# Altium schematic sheet PixelClockPanel.SchDoc
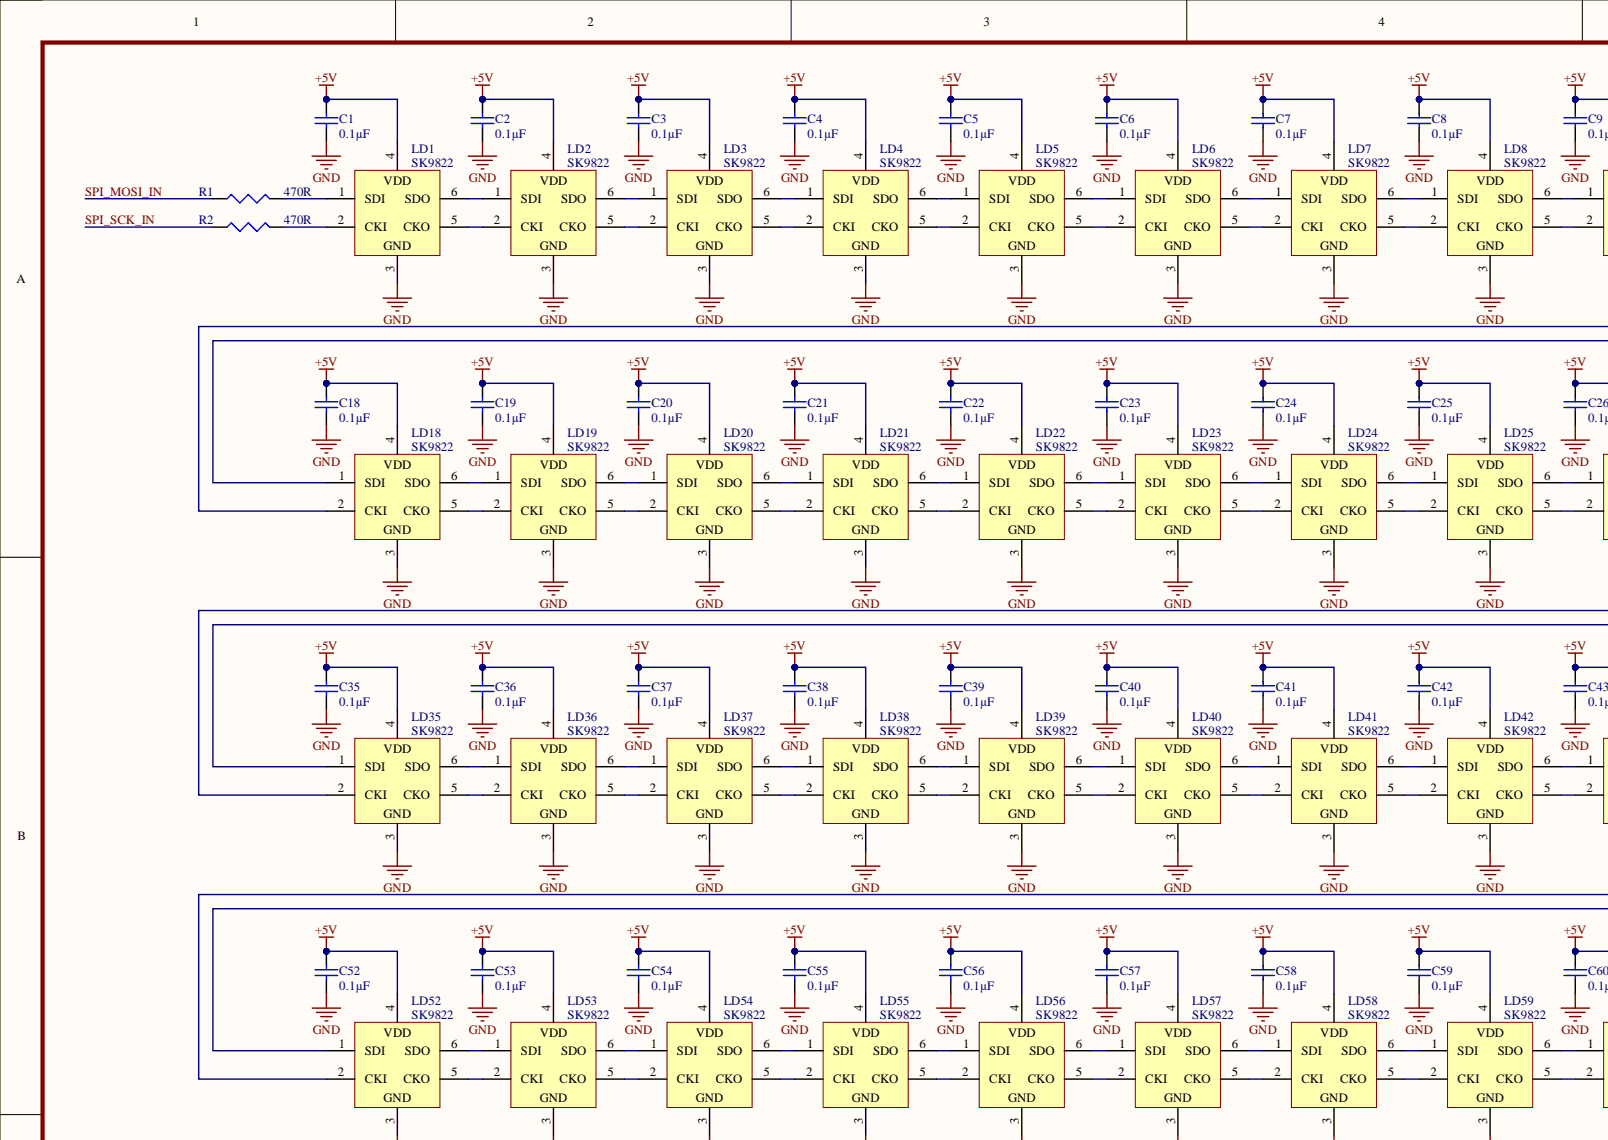
[diagram p0: PixelClockPanel.SchDoc, top left]
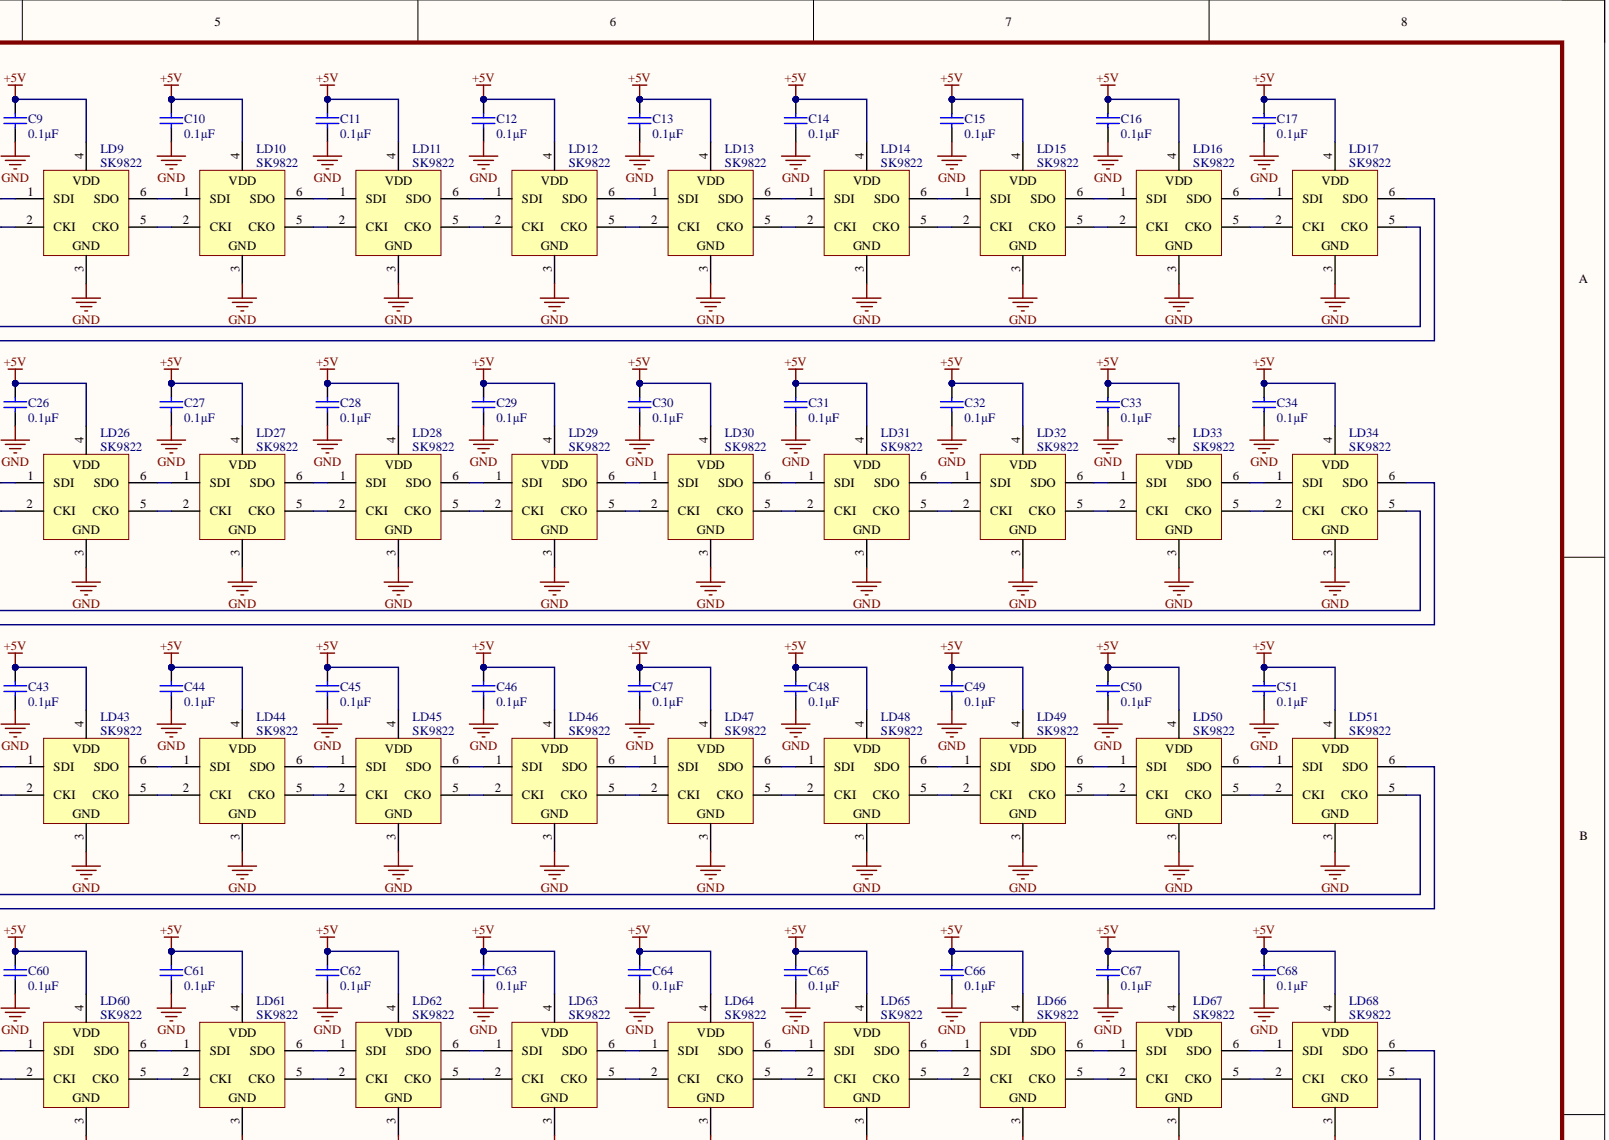
[diagram p1: PixelClockPanel.SchDoc, top right]
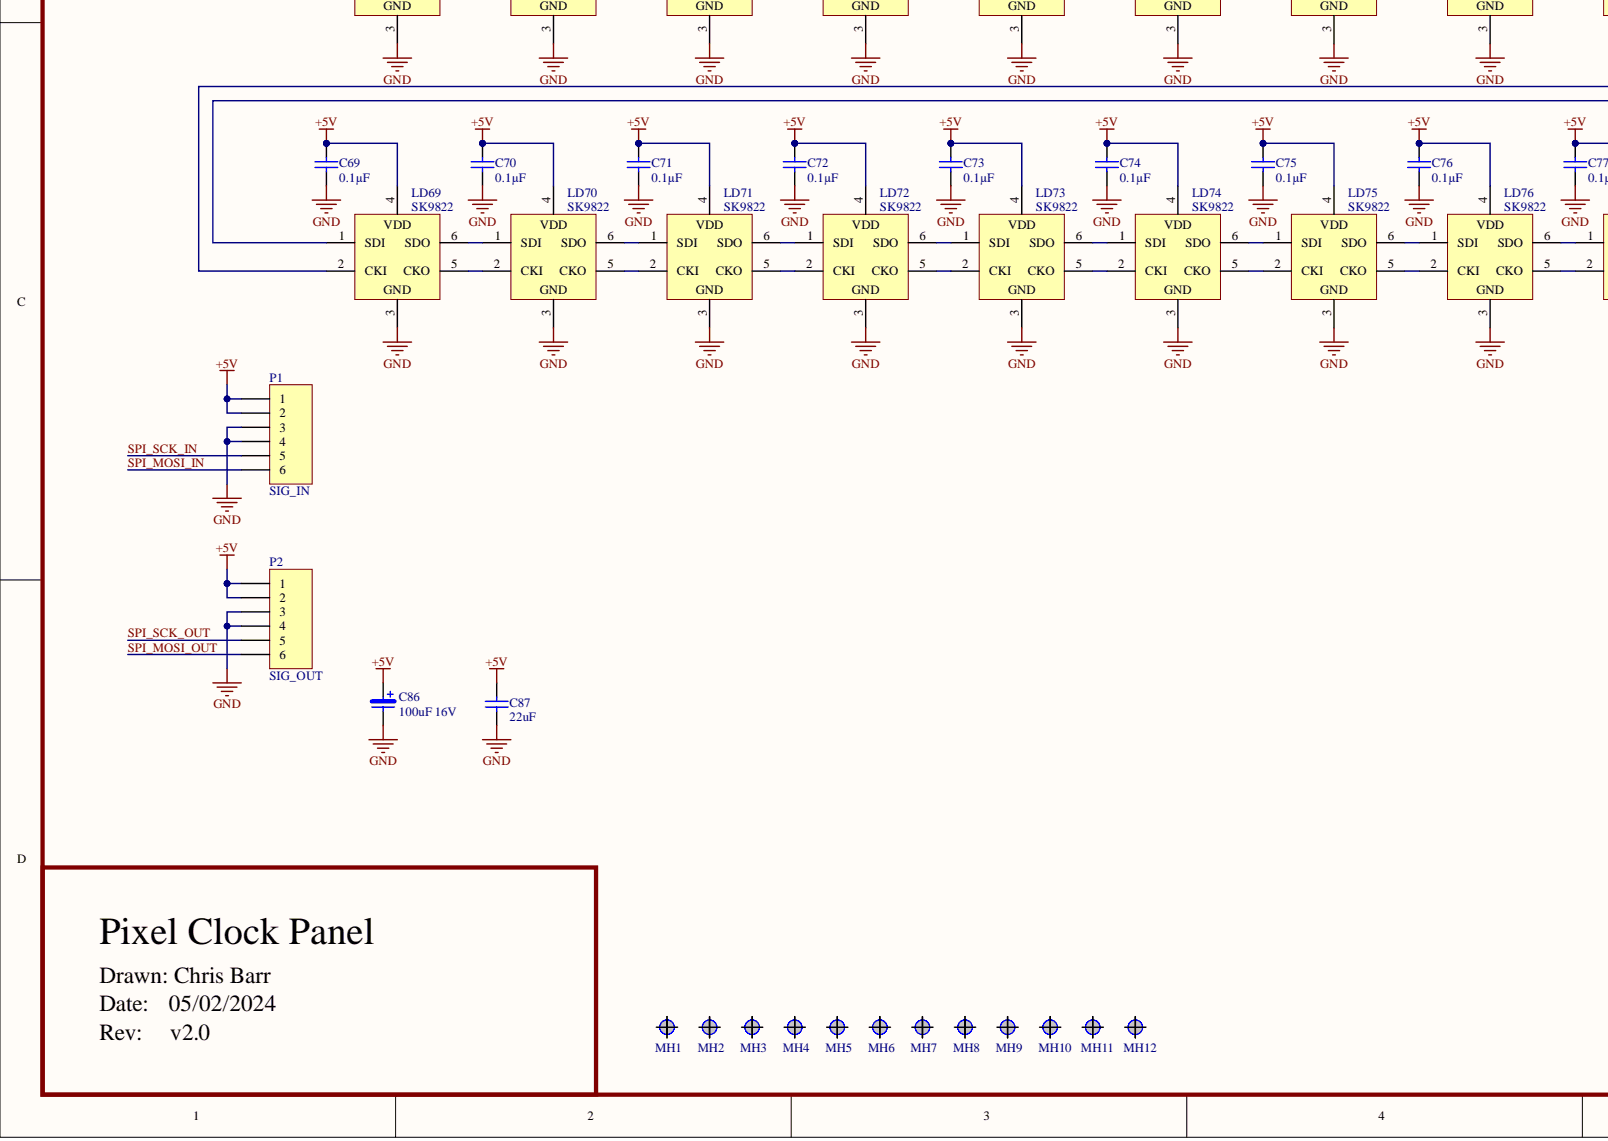
[diagram p2: PixelClockPanel.SchDoc, bottom left]
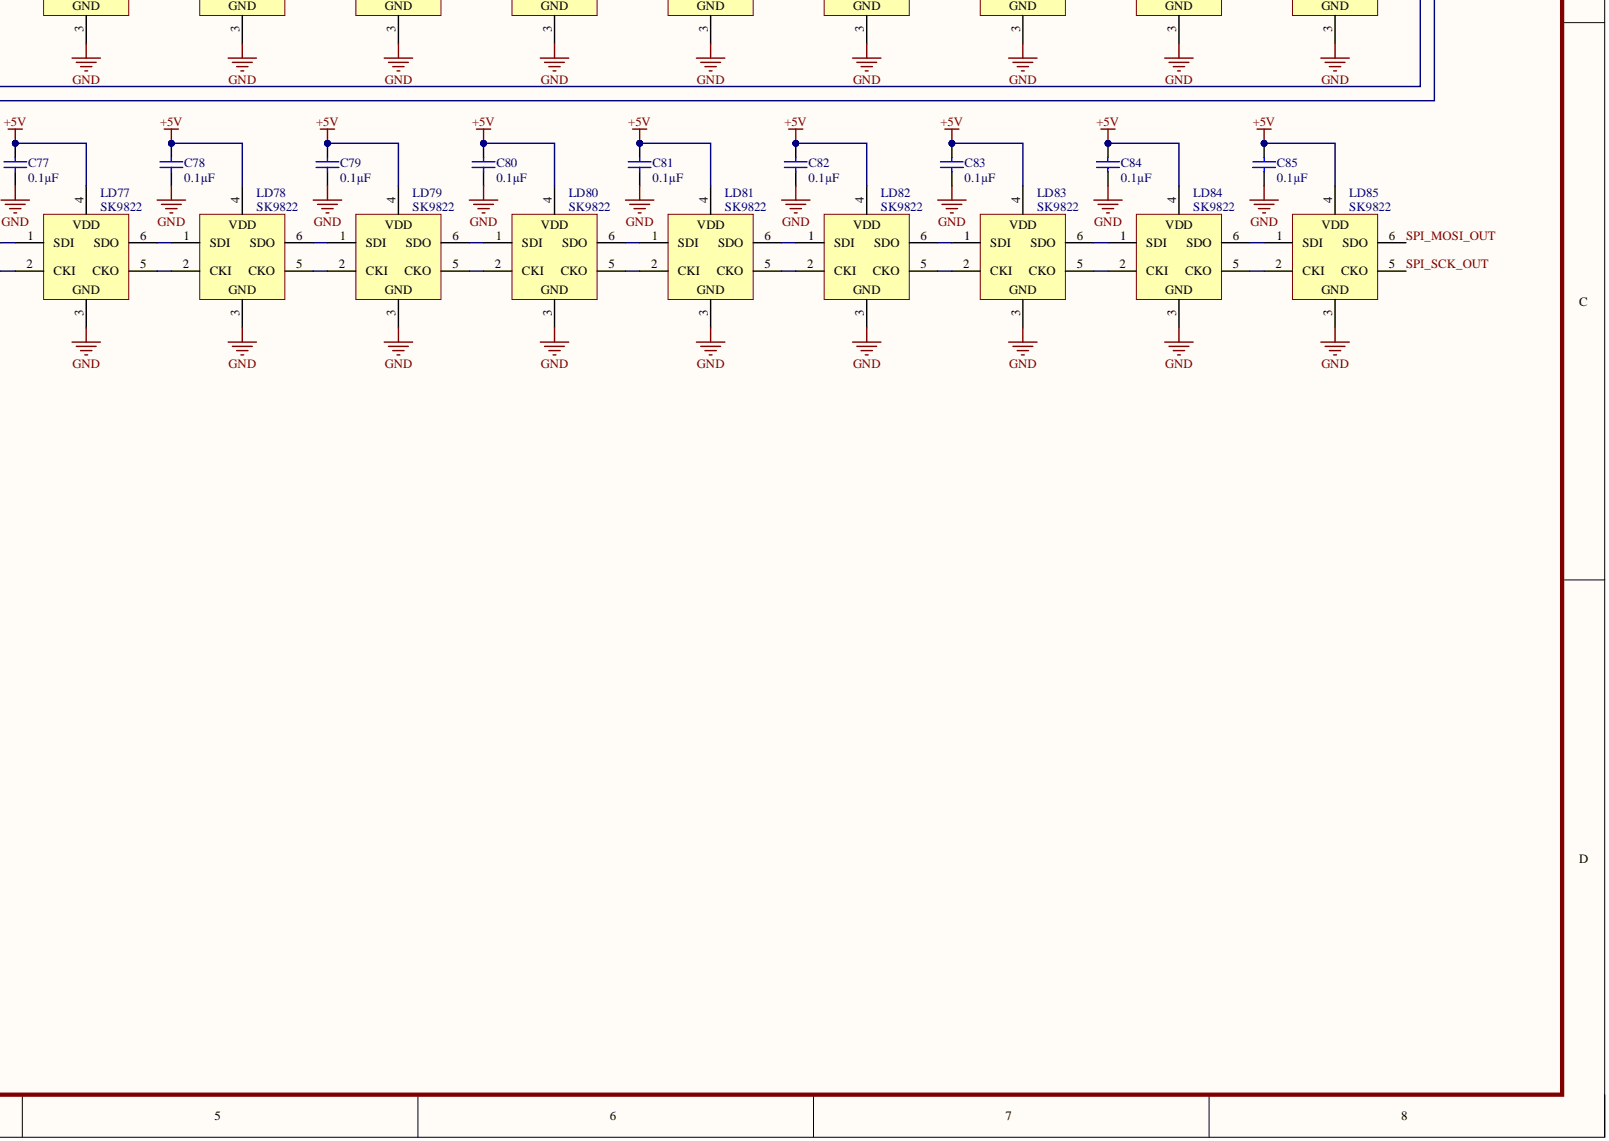
[diagram p3: PixelClockPanel.SchDoc, bottom right]

## Parts
C1  value 0.1µF  [CAPC1608X90N]  (hidden comment: Capacitor)
C2  value 0.1µF  [CAPC1608X90N]  (hidden comment: Capacitor)
C3  value 0.1µF  [CAPC1608X90N]  (hidden comment: Capacitor)
C4  value 0.1µF  [CAPC1608X90N]  (hidden comment: Capacitor)
C5  value 0.1µF  [CAPC1608X90N]  (hidden comment: Capacitor)
C6  value 0.1µF  [CAPC1608X90N]  (hidden comment: Capacitor)
C7  value 0.1µF  [CAPC1608X90N]  (hidden comment: Capacitor)
C8  value 0.1µF  [CAPC1608X90N]  (hidden comment: Capacitor)
C9  value 0.1µF  [CAPC1608X90N]  (hidden comment: Capacitor)
C10  value 0.1µF  [CAPC1608X90N]  (hidden comment: Capacitor)
C11  value 0.1µF  [CAPC1608X90N]  (hidden comment: Capacitor)
C12  value 0.1µF  [CAPC1608X90N]  (hidden comment: Capacitor)
C13  value 0.1µF  [CAPC1608X90N]  (hidden comment: Capacitor)
C14  value 0.1µF  [CAPC1608X90N]  (hidden comment: Capacitor)
C15  value 0.1µF  [CAPC1608X90N]  (hidden comment: Capacitor)
C16  value 0.1µF  [CAPC1608X90N]  (hidden comment: Capacitor)
C17  value 0.1µF  [CAPC1608X90N]  (hidden comment: Capacitor)
C18  value 0.1µF  [CAPC1608X90N]  (hidden comment: Capacitor)
C19  value 0.1µF  [CAPC1608X90N]  (hidden comment: Capacitor)
C20  value 0.1µF  [CAPC1608X90N]  (hidden comment: Capacitor)
C21  value 0.1µF  [CAPC1608X90N]  (hidden comment: Capacitor)
C22  value 0.1µF  [CAPC1608X90N]  (hidden comment: Capacitor)
C23  value 0.1µF  [CAPC1608X90N]  (hidden comment: Capacitor)
C24  value 0.1µF  [CAPC1608X90N]  (hidden comment: Capacitor)
C25  value 0.1µF  [CAPC1608X90N]  (hidden comment: Capacitor)
C26  value 0.1µF  [CAPC1608X90N]  (hidden comment: Capacitor)
C27  value 0.1µF  [CAPC1608X90N]  (hidden comment: Capacitor)
C28  value 0.1µF  [CAPC1608X90N]  (hidden comment: Capacitor)
C29  value 0.1µF  [CAPC1608X90N]  (hidden comment: Capacitor)
C30  value 0.1µF  [CAPC1608X90N]  (hidden comment: Capacitor)
C31  value 0.1µF  [CAPC1608X90N]  (hidden comment: Capacitor)
C32  value 0.1µF  [CAPC1608X90N]  (hidden comment: Capacitor)
C33  value 0.1µF  [CAPC1608X90N]  (hidden comment: Capacitor)
C34  value 0.1µF  [CAPC1608X90N]  (hidden comment: Capacitor)
C35  value 0.1µF  [CAPC1608X90N]  (hidden comment: Capacitor)
C36  value 0.1µF  [CAPC1608X90N]  (hidden comment: Capacitor)
C37  value 0.1µF  [CAPC1608X90N]  (hidden comment: Capacitor)
C38  value 0.1µF  [CAPC1608X90N]  (hidden comment: Capacitor)
C39  value 0.1µF  [CAPC1608X90N]  (hidden comment: Capacitor)
C40  value 0.1µF  [CAPC1608X90N]  (hidden comment: Capacitor)
C41  value 0.1µF  [CAPC1608X90N]  (hidden comment: Capacitor)
C42  value 0.1µF  [CAPC1608X90N]  (hidden comment: Capacitor)
C43  value 0.1µF  [CAPC1608X90N]  (hidden comment: Capacitor)
C44  value 0.1µF  [CAPC1608X90N]  (hidden comment: Capacitor)
C45  value 0.1µF  [CAPC1608X90N]  (hidden comment: Capacitor)
C46  value 0.1µF  [CAPC1608X90N]  (hidden comment: Capacitor)
C47  value 0.1µF  [CAPC1608X90N]  (hidden comment: Capacitor)
C48  value 0.1µF  [CAPC1608X90N]  (hidden comment: Capacitor)
C49  value 0.1µF  [CAPC1608X90N]  (hidden comment: Capacitor)
C50  value 0.1µF  [CAPC1608X90N]  (hidden comment: Capacitor)
C51  value 0.1µF  [CAPC1608X90N]  (hidden comment: Capacitor)
C52  value 0.1µF  [CAPC1608X90N]  (hidden comment: Capacitor)
C53  value 0.1µF  [CAPC1608X90N]  (hidden comment: Capacitor)
C54  value 0.1µF  [CAPC1608X90N]  (hidden comment: Capacitor)
C55  value 0.1µF  [CAPC1608X90N]  (hidden comment: Capacitor)
C56  value 0.1µF  [CAPC1608X90N]  (hidden comment: Capacitor)
C57  value 0.1µF  [CAPC1608X90N]  (hidden comment: Capacitor)
C58  value 0.1µF  [CAPC1608X90N]  (hidden comment: Capacitor)
C59  value 0.1µF  [CAPC1608X90N]  (hidden comment: Capacitor)
C60  value 0.1µF  [CAPC1608X90N]  (hidden comment: Capacitor)
C61  value 0.1µF  [CAPC1608X90N]  (hidden comment: Capacitor)
C62  value 0.1µF  [CAPC1608X90N]  (hidden comment: Capacitor)
C63  value 0.1µF  [CAPC1608X90N]  (hidden comment: Capacitor)
C64  value 0.1µF  [CAPC1608X90N]  (hidden comment: Capacitor)
C65  value 0.1µF  [CAPC1608X90N]  (hidden comment: Capacitor)
C66  value 0.1µF  [CAPC1608X90N]  (hidden comment: Capacitor)
C67  value 0.1µF  [CAPC1608X90N]  (hidden comment: Capacitor)
C68  value 0.1µF  [CAPC1608X90N]  (hidden comment: Capacitor)
C69  value 0.1µF  [CAPC1608X90N]  (hidden comment: Capacitor)
C70  value 0.1µF  [CAPC1608X90N]  (hidden comment: Capacitor)
C71  value 0.1µF  [CAPC1608X90N]  (hidden comment: Capacitor)
C72  value 0.1µF  [CAPC1608X90N]  (hidden comment: Capacitor)
C73  value 0.1µF  [CAPC1608X90N]  (hidden comment: Capacitor)
C74  value 0.1µF  [CAPC1608X90N]  (hidden comment: Capacitor)
C75  value 0.1µF  [CAPC1608X90N]  (hidden comment: Capacitor)
C76  value 0.1µF  [CAPC1608X90N]  (hidden comment: Capacitor)
C77  value 0.1µF  [CAPC1608X90N]  (hidden comment: Capacitor)
C78  value 0.1µF  [CAPC1608X90N]  (hidden comment: Capacitor)
C79  value 0.1µF  [CAPC1608X90N]  (hidden comment: Capacitor)
C80  value 0.1µF  [CAPC1608X90N]  (hidden comment: Capacitor)
C81  value 0.1µF  [CAPC1608X90N]  (hidden comment: Capacitor)
C82  value 0.1µF  [CAPC1608X90N]  (hidden comment: Capacitor)
C83  value 0.1µF  [CAPC1608X90N]  (hidden comment: Capacitor)
C84  value 0.1µF  [CAPC1608X90N]  (hidden comment: Capacitor)
C85  value 0.1µF  [CAPC1608X90N]  (hidden comment: Capacitor)
C86  value 100uF 16V  [CAP EL PANASONIC]  (hidden comment: CapE-6.3-100uF-16V)  (no BOM)
C87  value 22uF  [CAP 3225-1210]  (hidden comment: CapC-1210-22uF-16V)  (no BOM)
LD1  SK9822  [LED SK9822 APA102]
LD2  SK9822  [LED SK9822 APA102]
LD3  SK9822  [LED SK9822 APA102]
LD4  SK9822  [LED SK9822 APA102]
LD5  SK9822  [LED SK9822 APA102]
LD6  SK9822  [LED SK9822 APA102]
LD7  SK9822  [LED SK9822 APA102]
LD8  SK9822  [LED SK9822 APA102]
LD9  SK9822  [LED SK9822 APA102]
LD10  SK9822  [LED SK9822 APA102]
LD11  SK9822  [LED SK9822 APA102]
LD12  SK9822  [LED SK9822 APA102]
LD13  SK9822  [LED SK9822 APA102]
LD14  SK9822  [LED SK9822 APA102]
LD15  SK9822  [LED SK9822 APA102]
LD16  SK9822  [LED SK9822 APA102]
LD17  SK9822  [LED SK9822 APA102]
LD18  SK9822  [LED SK9822 APA102]
LD19  SK9822  [LED SK9822 APA102]
LD20  SK9822  [LED SK9822 APA102]
LD21  SK9822  [LED SK9822 APA102]
LD22  SK9822  [LED SK9822 APA102]
LD23  SK9822  [LED SK9822 APA102]
LD24  SK9822  [LED SK9822 APA102]
LD25  SK9822  [LED SK9822 APA102]
LD26  SK9822  [LED SK9822 APA102]
LD27  SK9822  [LED SK9822 APA102]
LD28  SK9822  [LED SK9822 APA102]
LD29  SK9822  [LED SK9822 APA102]
LD30  SK9822  [LED SK9822 APA102]
LD31  SK9822  [LED SK9822 APA102]
LD32  SK9822  [LED SK9822 APA102]
LD33  SK9822  [LED SK9822 APA102]
LD34  SK9822  [LED SK9822 APA102]
LD35  SK9822  [LED SK9822 APA102]
LD36  SK9822  [LED SK9822 APA102]
LD37  SK9822  [LED SK9822 APA102]
LD38  SK9822  [LED SK9822 APA102]
LD39  SK9822  [LED SK9822 APA102]
LD40  SK9822  [LED SK9822 APA102]
LD41  SK9822  [LED SK9822 APA102]
LD42  SK9822  [LED SK9822 APA102]
LD43  SK9822  [LED SK9822 APA102]
LD44  SK9822  [LED SK9822 APA102]
LD45  SK9822  [LED SK9822 APA102]
LD46  SK9822  [LED SK9822 APA102]
LD47  SK9822  [LED SK9822 APA102]
LD48  SK9822  [LED SK9822 APA102]
LD49  SK9822  [LED SK9822 APA102]
LD50  SK9822  [LED SK9822 APA102]
LD51  SK9822  [LED SK9822 APA102]
LD52  SK9822  [LED SK9822 APA102]
LD53  SK9822  [LED SK9822 APA102]
LD54  SK9822  [LED SK9822 APA102]
LD55  SK9822  [LED SK9822 APA102]
LD56  SK9822  [LED SK9822 APA102]
LD57  SK9822  [LED SK9822 APA102]
LD58  SK9822  [LED SK9822 APA102]
LD59  SK9822  [LED SK9822 APA102]
LD60  SK9822  [LED SK9822 APA102]
LD61  SK9822  [LED SK9822 APA102]
LD62  SK9822  [LED SK9822 APA102]
LD63  SK9822  [LED SK9822 APA102]
LD64  SK9822  [LED SK9822 APA102]
LD65  SK9822  [LED SK9822 APA102]
LD66  SK9822  [LED SK9822 APA102]
LD67  SK9822  [LED SK9822 APA102]
LD68  SK9822  [LED SK9822 APA102]
LD69  SK9822  [LED SK9822 APA102]
LD70  SK9822  [LED SK9822 APA102]
LD71  SK9822  [LED SK9822 APA102]
LD72  SK9822  [LED SK9822 APA102]
LD73  SK9822  [LED SK9822 APA102]
LD74  SK9822  [LED SK9822 APA102]
LD75  SK9822  [LED SK9822 APA102]
LD76  SK9822  [LED SK9822 APA102]
LD77  SK9822  [LED SK9822 APA102]
LD78  SK9822  [LED SK9822 APA102]
LD79  SK9822  [LED SK9822 APA102]
LD80  SK9822  [LED SK9822 APA102]
LD81  SK9822  [LED SK9822 APA102]
LD82  SK9822  [LED SK9822 APA102]
LD83  SK9822  [LED SK9822 APA102]
LD84  SK9822  [LED SK9822 APA102]
LD85  SK9822  [LED SK9822 APA102]
MH1  Screw Hole  [M3-Hole-2]  (value not drawn)  (no BOM)
MH2  Screw Hole  [M3-Hole-2]  (value not drawn)  (no BOM)
MH3  Screw Hole  [M3-Hole-2]  (value not drawn)  (no BOM)
MH4  Screw Hole  [M3-Hole-2]  (value not drawn)  (no BOM)
MH5  Screw Hole  [M3-Hole-2]  (value not drawn)  (no BOM)
MH6  Screw Hole  [M3-Hole-2]  (value not drawn)  (no BOM)
MH7  Screw Hole  [M3-Hole-2]  (value not drawn)  (no BOM)
MH8  Screw Hole  [M3-Hole-2]  (value not drawn)  (no BOM)
MH9  Screw Hole  [M3-Hole-2]  (value not drawn)  (no BOM)
MH10  Screw Hole  [M3-Hole-2]  (value not drawn)  (no BOM)
MH11  Screw Hole  [M3-Hole-2]  (value not drawn)  (no BOM)
MH12  Screw Hole  [M3-Hole-2]  (value not drawn)  (no BOM)
P1  SIG_IN  [JST S6B-XH-SM4-TB]
P2  SIG_OUT  [JST S6B-XH-SM4-TB]
R1  value 470R  [RES 1608-0603]  (hidden comment: Resistor)
R2  value 470R  [RES 1608-0603]  (hidden comment: Resistor)

## Nets
+5V: C1.2 C2.2 C3.2 C4.2 C5.2 C6.2 C7.2 C8.2 C9.2 C10.2 C11.2 C12.2 C13.2 C14.2 C15.2 C16.2 C17.2 C18.2 C19.2 C20.2 C21.2 C22.2 C23.2 C24.2 C25.2 C26.2 C27.2 C28.2 C29.2 C30.2 C31.2 C32.2 C33.2 C34.2 C35.2 C36.2 C37.2 C38.2 C39.2 C40.2 C41.2 C42.2 C43.2 C44.2 C45.2 C46.2 C47.2 C48.2 C49.2 C50.2 C51.2 C52.2 C53.2 C54.2 C55.2 C56.2 C57.2 C58.2 C59.2 C60.2 C61.2 C62.2 C63.2 C64.2 C65.2 C66.2 C67.2 C68.2 C69.2 C70.2 C71.2 C72.2 C73.2 C74.2 C75.2 C76.2 C77.2 C78.2 C79.2 C80.2 C81.2 C82.2 C83.2 C84.2 C85.2 C86.1 C87.2 LD1.4 LD2.4 LD3.4 LD4.4 LD5.4 LD6.4 LD7.4 LD8.4 LD9.4 LD10.4 LD11.4 LD12.4 LD13.4 LD14.4 LD15.4 LD16.4 LD17.4 LD18.4 LD19.4 LD20.4 LD21.4 LD22.4 LD23.4 LD24.4 LD25.4 LD26.4 LD27.4 LD28.4 LD29.4 LD30.4 LD31.4 LD32.4 LD33.4 LD34.4 LD35.4 LD36.4 LD37.4 LD38.4 LD39.4 LD40.4 LD41.4 LD42.4 LD43.4 LD44.4 LD45.4 LD46.4 LD47.4 LD48.4 LD49.4 LD50.4 LD51.4 LD52.4 LD53.4 LD54.4 LD55.4 LD56.4 LD57.4 LD58.4 LD59.4 LD60.4 LD61.4 LD62.4 LD63.4 LD64.4 LD65.4 LD66.4 LD67.4 LD68.4 LD69.4 LD70.4 LD71.4 LD72.4 LD73.4 LD74.4 LD75.4 LD76.4 LD77.4 LD78.4 LD79.4 LD80.4 LD81.4 LD82.4 LD83.4 LD84.4 LD85.4 P1.1 P1.2 P2.1 P2.2
GND: C1.1 C2.1 C3.1 C4.1 C5.1 C6.1 C7.1 C8.1 C9.1 C10.1 C11.1 C12.1 C13.1 C14.1 C15.1 C16.1 C17.1 C18.1 C19.1 C20.1 C21.1 C22.1 C23.1 C24.1 C25.1 C26.1 C27.1 C28.1 C29.1 C30.1 C31.1 C32.1 C33.1 C34.1 C35.1 C36.1 C37.1 C38.1 C39.1 C40.1 C41.1 C42.1 C43.1 C44.1 C45.1 C46.1 C47.1 C48.1 C49.1 C50.1 C51.1 C52.1 C53.1 C54.1 C55.1 C56.1 C57.1 C58.1 C59.1 C60.1 C61.1 C62.1 C63.1 C64.1 C65.1 C66.1 C67.1 C68.1 C69.1 C70.1 C71.1 C72.1 C73.1 C74.1 C75.1 C76.1 C77.1 C78.1 C79.1 C80.1 C81.1 C82.1 C83.1 C84.1 C85.1 C86.2 C87.1 LD1.3 LD2.3 LD3.3 LD4.3 LD5.3 LD6.3 LD7.3 LD8.3 LD9.3 LD10.3 LD11.3 LD12.3 LD13.3 LD14.3 LD15.3 LD16.3 LD17.3 LD18.3 LD19.3 LD20.3 LD21.3 LD22.3 LD23.3 LD24.3 LD25.3 LD26.3 LD27.3 LD28.3 LD29.3 LD30.3 LD31.3 LD32.3 LD33.3 LD34.3 LD35.3 LD36.3 LD37.3 LD38.3 LD39.3 LD40.3 LD41.3 LD42.3 LD43.3 LD44.3 LD45.3 LD46.3 LD47.3 LD48.3 LD49.3 LD50.3 LD51.3 LD52.3 LD53.3 LD54.3 LD55.3 LD56.3 LD57.3 LD58.3 LD59.3 LD60.3 LD61.3 LD62.3 LD63.3 LD64.3 LD65.3 LD66.3 LD67.3 LD68.3 LD69.3 LD70.3 LD71.3 LD72.3 LD73.3 LD74.3 LD75.3 LD76.3 LD77.3 LD78.3 LD79.3 LD80.3 LD81.3 LD82.3 LD83.3 LD84.3 LD85.3 P1.3 P1.4 P2.3 P2.4
SPI_MOSI_IN: P1.6 R1.1
SPI_MOSI_OUT: LD85.6(SDO) P2.6
SPI_SCK_IN: P1.5 R2.1
SPI_SCK_OUT: LD85.5(CKO) P2.5
NetLD1_1: LD1.1(SDI) R1.2
NetLD1_2: LD1.2(CKI) R2.2
NetLD1_5: LD1.5(CKO) LD2.2(CKI)
NetLD1_6: LD1.6(SDO) LD2.1(SDI)
NetLD2_5: LD2.5(CKO) LD3.2(CKI)
NetLD2_6: LD2.6(SDO) LD3.1(SDI)
NetLD3_5: LD3.5(CKO) LD4.2(CKI)
NetLD3_6: LD3.6(SDO) LD4.1(SDI)
NetLD4_5: LD4.5(CKO) LD5.2(CKI)
NetLD4_6: LD4.6(SDO) LD5.1(SDI)
NetLD5_5: LD5.5(CKO) LD6.2(CKI)
NetLD5_6: LD5.6(SDO) LD6.1(SDI)
NetLD6_5: LD6.5(CKO) LD7.2(CKI)
NetLD6_6: LD6.6(SDO) LD7.1(SDI)
NetLD7_5: LD7.5(CKO) LD8.2(CKI)
NetLD7_6: LD7.6(SDO) LD8.1(SDI)
NetLD8_5: LD8.5(CKO) LD9.2(CKI)
NetLD8_6: LD8.6(SDO) LD9.1(SDI)
NetLD9_5: LD9.5(CKO) LD10.2(CKI)
NetLD9_6: LD9.6(SDO) LD10.1(SDI)
NetLD10_5: LD10.5(CKO) LD11.2(CKI)
NetLD10_6: LD10.6(SDO) LD11.1(SDI)
NetLD11_5: LD11.5(CKO) LD12.2(CKI)
NetLD11_6: LD11.6(SDO) LD12.1(SDI)
NetLD12_5: LD12.5(CKO) LD13.2(CKI)
NetLD12_6: LD12.6(SDO) LD13.1(SDI)
NetLD13_5: LD13.5(CKO) LD14.2(CKI)
NetLD13_6: LD13.6(SDO) LD14.1(SDI)
NetLD14_5: LD14.5(CKO) LD15.2(CKI)
NetLD14_6: LD14.6(SDO) LD15.1(SDI)
NetLD15_5: LD15.5(CKO) LD16.2(CKI)
NetLD15_6: LD15.6(SDO) LD16.1(SDI)
NetLD16_5: LD16.5(CKO) LD17.2(CKI)
NetLD16_6: LD16.6(SDO) LD17.1(SDI)
NetLD17_5: LD17.5(CKO) LD18.2(CKI)
NetLD17_6: LD17.6(SDO) LD18.1(SDI)
NetLD18_5: LD18.5(CKO) LD19.2(CKI)
NetLD18_6: LD18.6(SDO) LD19.1(SDI)
NetLD19_5: LD19.5(CKO) LD20.2(CKI)
NetLD19_6: LD19.6(SDO) LD20.1(SDI)
NetLD20_5: LD20.5(CKO) LD21.2(CKI)
NetLD20_6: LD20.6(SDO) LD21.1(SDI)
NetLD21_5: LD21.5(CKO) LD22.2(CKI)
NetLD21_6: LD21.6(SDO) LD22.1(SDI)
NetLD22_5: LD22.5(CKO) LD23.2(CKI)
NetLD22_6: LD22.6(SDO) LD23.1(SDI)
NetLD23_5: LD23.5(CKO) LD24.2(CKI)
NetLD23_6: LD23.6(SDO) LD24.1(SDI)
NetLD24_5: LD24.5(CKO) LD25.2(CKI)
NetLD24_6: LD24.6(SDO) LD25.1(SDI)
NetLD25_5: LD25.5(CKO) LD26.2(CKI)
NetLD25_6: LD25.6(SDO) LD26.1(SDI)
NetLD26_5: LD26.5(CKO) LD27.2(CKI)
NetLD26_6: LD26.6(SDO) LD27.1(SDI)
NetLD27_5: LD27.5(CKO) LD28.2(CKI)
NetLD27_6: LD27.6(SDO) LD28.1(SDI)
NetLD28_5: LD28.5(CKO) LD29.2(CKI)
NetLD28_6: LD28.6(SDO) LD29.1(SDI)
NetLD29_5: LD29.5(CKO) LD30.2(CKI)
NetLD29_6: LD29.6(SDO) LD30.1(SDI)
NetLD30_5: LD30.5(CKO) LD31.2(CKI)
NetLD30_6: LD30.6(SDO) LD31.1(SDI)
NetLD31_5: LD31.5(CKO) LD32.2(CKI)
NetLD31_6: LD31.6(SDO) LD32.1(SDI)
NetLD32_5: LD32.5(CKO) LD33.2(CKI)
NetLD32_6: LD32.6(SDO) LD33.1(SDI)
NetLD33_5: LD33.5(CKO) LD34.2(CKI)
NetLD33_6: LD33.6(SDO) LD34.1(SDI)
NetLD34_5: LD34.5(CKO) LD35.2(CKI)
NetLD34_6: LD34.6(SDO) LD35.1(SDI)
NetLD35_5: LD35.5(CKO) LD36.2(CKI)
NetLD35_6: LD35.6(SDO) LD36.1(SDI)
NetLD36_5: LD36.5(CKO) LD37.2(CKI)
NetLD36_6: LD36.6(SDO) LD37.1(SDI)
NetLD37_5: LD37.5(CKO) LD38.2(CKI)
NetLD37_6: LD37.6(SDO) LD38.1(SDI)
NetLD38_5: LD38.5(CKO) LD39.2(CKI)
NetLD38_6: LD38.6(SDO) LD39.1(SDI)
NetLD39_5: LD39.5(CKO) LD40.2(CKI)
NetLD39_6: LD39.6(SDO) LD40.1(SDI)
NetLD40_5: LD40.5(CKO) LD41.2(CKI)
NetLD40_6: LD40.6(SDO) LD41.1(SDI)
NetLD41_5: LD41.5(CKO) LD42.2(CKI)
NetLD41_6: LD41.6(SDO) LD42.1(SDI)
NetLD42_5: LD42.5(CKO) LD43.2(CKI)
NetLD42_6: LD42.6(SDO) LD43.1(SDI)
NetLD43_5: LD43.5(CKO) LD44.2(CKI)
NetLD43_6: LD43.6(SDO) LD44.1(SDI)
NetLD44_5: LD44.5(CKO) LD45.2(CKI)
NetLD44_6: LD44.6(SDO) LD45.1(SDI)
NetLD45_5: LD45.5(CKO) LD46.2(CKI)
NetLD45_6: LD45.6(SDO) LD46.1(SDI)
NetLD46_5: LD46.5(CKO) LD47.2(CKI)
NetLD46_6: LD46.6(SDO) LD47.1(SDI)
NetLD47_5: LD47.5(CKO) LD48.2(CKI)
NetLD47_6: LD47.6(SDO) LD48.1(SDI)
NetLD48_5: LD48.5(CKO) LD49.2(CKI)
NetLD48_6: LD48.6(SDO) LD49.1(SDI)
NetLD49_5: LD49.5(CKO) LD50.2(CKI)
NetLD49_6: LD49.6(SDO) LD50.1(SDI)
NetLD50_5: LD50.5(CKO) LD51.2(CKI)
NetLD50_6: LD50.6(SDO) LD51.1(SDI)
NetLD51_5: LD51.5(CKO) LD52.2(CKI)
NetLD51_6: LD51.6(SDO) LD52.1(SDI)
NetLD52_5: LD52.5(CKO) LD53.2(CKI)
NetLD52_6: LD52.6(SDO) LD53.1(SDI)
NetLD53_5: LD53.5(CKO) LD54.2(CKI)
NetLD53_6: LD53.6(SDO) LD54.1(SDI)
NetLD54_5: LD54.5(CKO) LD55.2(CKI)
NetLD54_6: LD54.6(SDO) LD55.1(SDI)
NetLD55_5: LD55.5(CKO) LD56.2(CKI)
NetLD55_6: LD55.6(SDO) LD56.1(SDI)
NetLD56_5: LD56.5(CKO) LD57.2(CKI)
NetLD56_6: LD56.6(SDO) LD57.1(SDI)
NetLD57_5: LD57.5(CKO) LD58.2(CKI)
NetLD57_6: LD57.6(SDO) LD58.1(SDI)
NetLD58_5: LD58.5(CKO) LD59.2(CKI)
NetLD58_6: LD58.6(SDO) LD59.1(SDI)
NetLD59_5: LD59.5(CKO) LD60.2(CKI)
NetLD59_6: LD59.6(SDO) LD60.1(SDI)
NetLD60_5: LD60.5(CKO) LD61.2(CKI)
NetLD60_6: LD60.6(SDO) LD61.1(SDI)
NetLD61_5: LD61.5(CKO) LD62.2(CKI)
NetLD61_6: LD61.6(SDO) LD62.1(SDI)
NetLD62_5: LD62.5(CKO) LD63.2(CKI)
NetLD62_6: LD62.6(SDO) LD63.1(SDI)
NetLD63_5: LD63.5(CKO) LD64.2(CKI)
NetLD63_6: LD63.6(SDO) LD64.1(SDI)
NetLD64_5: LD64.5(CKO) LD65.2(CKI)
NetLD64_6: LD64.6(SDO) LD65.1(SDI)
NetLD65_5: LD65.5(CKO) LD66.2(CKI)
NetLD65_6: LD65.6(SDO) LD66.1(SDI)
NetLD66_5: LD66.5(CKO) LD67.2(CKI)
NetLD66_6: LD66.6(SDO) LD67.1(SDI)
NetLD67_5: LD67.5(CKO) LD68.2(CKI)
NetLD67_6: LD67.6(SDO) LD68.1(SDI)
NetLD68_5: LD68.5(CKO) LD69.2(CKI)
NetLD68_6: LD68.6(SDO) LD69.1(SDI)
NetLD69_5: LD69.5(CKO) LD70.2(CKI)
NetLD69_6: LD69.6(SDO) LD70.1(SDI)
NetLD70_5: LD70.5(CKO) LD71.2(CKI)
NetLD70_6: LD70.6(SDO) LD71.1(SDI)
NetLD71_5: LD71.5(CKO) LD72.2(CKI)
NetLD71_6: LD71.6(SDO) LD72.1(SDI)
NetLD72_5: LD72.5(CKO) LD73.2(CKI)
NetLD72_6: LD72.6(SDO) LD73.1(SDI)
NetLD73_5: LD73.5(CKO) LD74.2(CKI)
NetLD73_6: LD73.6(SDO) LD74.1(SDI)
NetLD74_5: LD74.5(CKO) LD75.2(CKI)
NetLD74_6: LD74.6(SDO) LD75.1(SDI)
NetLD75_5: LD75.5(CKO) LD76.2(CKI)
NetLD75_6: LD75.6(SDO) LD76.1(SDI)
NetLD76_5: LD76.5(CKO) LD77.2(CKI)
NetLD76_6: LD76.6(SDO) LD77.1(SDI)
NetLD77_5: LD77.5(CKO) LD78.2(CKI)
NetLD77_6: LD77.6(SDO) LD78.1(SDI)
NetLD78_5: LD78.5(CKO) LD79.2(CKI)
NetLD78_6: LD78.6(SDO) LD79.1(SDI)
NetLD79_5: LD79.5(CKO) LD80.2(CKI)
NetLD79_6: LD79.6(SDO) LD80.1(SDI)
NetLD80_5: LD80.5(CKO) LD81.2(CKI)
NetLD80_6: LD80.6(SDO) LD81.1(SDI)
NetLD81_5: LD81.5(CKO) LD82.2(CKI)
NetLD81_6: LD81.6(SDO) LD82.1(SDI)
NetLD82_5: LD82.5(CKO) LD83.2(CKI)
NetLD82_6: LD82.6(SDO) LD83.1(SDI)
NetLD83_5: LD83.5(CKO) LD84.2(CKI)
NetLD83_6: LD83.6(SDO) LD84.1(SDI)
NetLD84_5: LD84.5(CKO) LD85.2(CKI)
NetLD84_6: LD84.6(SDO) LD85.1(SDI)

Not connected: MH1 M3; MH2 M3; MH3 M3; MH4 M3; MH5 M3; MH6 M3; MH7 M3; MH8 M3; MH9 M3; MH10 M3; MH11 M3; MH12 M3

## Library data of the parts
C1-C85 = UMJ107BB7104KAHT: CAP CER 0.1UF 50V X7R 0603; Manufacturer 1: Taiyo Yuden; Database Table Name: TaiyoYuden$; Note: Approved sub OK; Tolerance: ±10%; Type: X5R; Component Kind: Standard; Library Name: Ceramic Cap DBLIB.DbLib; Manufacturer Part Number 1: UMJ107BB7104KAHT; Operating Temperature: -55°C ~ 125°C; Package: 0402; Package / Case: 0603 (1608 Metric); Revision: July-2002: Re-released for DXP Platform.; Signal Integrity: Cap Semi; Simulation: CAP; Size / Dimension: 0.063" L x 0.031" W (1.60mm x 0.80mm); Supplier 1: Digi-Key; Supplier Part Number 1: 587-4474-1-ND; Temperature Coefficient: X7R; Thickness (Max): 0.039" (1.00mm); Voltage: 50V
C86 = CapE-6.3-100uF-16V: Polarized Capacitor (Surface Mount); Note: Approved sub OK; Library Name: main_library.SCHLIB; Published: 8-Jun-2000; Revision: July-2002: Re-released for DXP Platform.; Signal Integrity: Cap Pol; Simulation: CAP; Supplier 1: Digi-Key; Supplier Part Number 1: 565-2204-1-ND; Publisher: Altium Limited
C87 = CapC-1210-22uF-16V: CAP CER 22UF 16V X7R 1210; Note: Approved sub OK; Tolerance: 10%; Voltage: 25V; Library Name: main_library.SCHLIB; Package: 1210; Revision: July-2002: Re-released for DXP Platform.; Supplier 1: Digi-Key; Supplier Part Number 1: 587-2784-1-ND; Type: X7R
LD1-LD85 = LED - SK9822: SK9822 5050 RGB LED; Component Kind: Standard; Library Name: main_library.SCHLIB
P1 P2 = Header 1X6: CONN HEADER SMD R/A 6POS 2.5MM; Manufacturer 1: Generic Header; Note: Approved sub OK; Component Kind: Standard; Library Name: main_library.SCHLIB; Signal Integrity: Connector; Supplier 1: Digi-Key; Supplier Part Number 1: 455-2264-1-ND
R1 R2 = RC0603FR-07470RL: RES SMD 470 OHM 1% 1/10W 0603; Manufacturer 1: Yageo; Database Table Name: 0603$; Note: Approved sub OK; Power (Watts): 0.1W, 1/10W; Temperature Coefficient: ±100ppm/°C; Component Kind: Standard; Composition: Thick Film; Features: Moisture Resistant; Height - Seated (Max): 0.022" (0.55mm); Library Name: Database_Libs1.DbLib; Manufacturer Part Number 1: RC0603FR-07470RL; Operating Temperature: -55°C ~ 155°C; Package / Case: 0603 (1608 Metric); Published: 8-Jun-2000; Revision: July-2002: Re-released for DXP Platform.; Signal Integrity: Res; Simulation: RESISTOR; Size / Dimension: 0.063" L x 0.031" W (1.60mm x 0.80mm); Supplier 1: Digi-Key; Supplier Part Number 1: 311-470HRCT-ND; Tolerance: ±1%; Publisher: Altium Limited
MH1-MH12 = Screw Hole: Library Name: main_library.SCHLIB
